# Altium schematic sheet main.SchDoc(main)
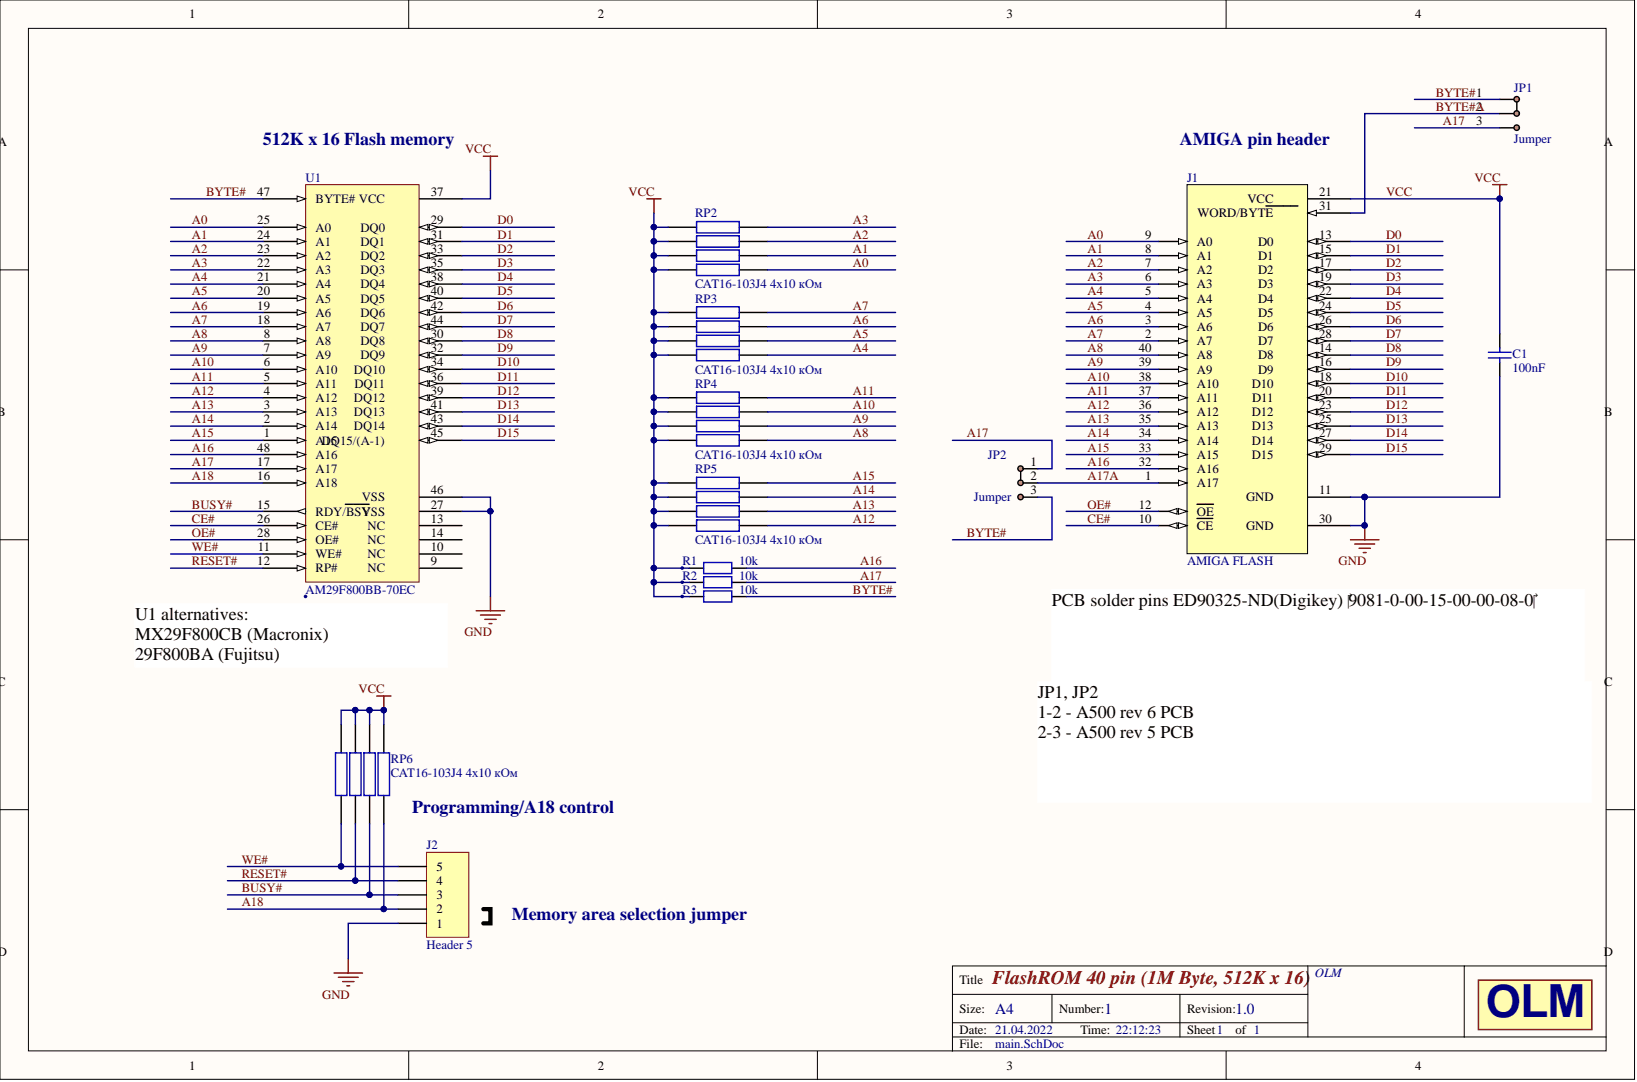
[diagram p0: main.SchDoc]

## Parts
C1  value 100nF  [SM/C_0603]  (hidden comment: Cap)
J1  AMIGA FLASH  [Header DIP40]
J2  Header 5  [HDR1X5]
JP1  Jumper  [Jumper-3-0.7x1.2-0.9mm]
JP2  Jumper  [Jumper-3-0.7x1.2-0.9mm]
R1  value 10k  [SM/R_0603]  (hidden comment: Резистор)
R2  value 10k  [SM/R_0603]  (hidden comment: Резистор)
R3  value 10k  [SM/R_0603]  (hidden comment: Резистор)
RP2  value CAT16-103J4 4х10 кОм  [RES_PACK_CAT16]  (hidden comment: Резисторная сборка)
RP3  value CAT16-103J4 4х10 кОм  [RES_PACK_CAT16]  (hidden comment: Резисторная сборка)
RP4  value CAT16-103J4 4х10 кОм  [RES_PACK_CAT16]  (hidden comment: Резисторная сборка)
RP5  value CAT16-103J4 4х10 кОм  [RES_PACK_CAT16]  (hidden comment: Резисторная сборка)
RP6  value CAT16-103J4 4х10 кОм  [RES_PACK_CAT16]  (hidden comment: Резисторная сборка)
U1  AM29F800BB-70EC  [TSOP-48]

## Nets
GND: C1.2 J1.11 J1.30 J2.1 U1.27 U1.46
A0: J1.9(A0) RP2.1 U1.25(A0)
A1: J1.8(A1) RP2.2 U1.24(A1)
A2: J1.7(A2) RP2.3 U1.23(A2)
A3: J1.6(A3) RP2.4 U1.22(A3)
A4: J1.5(A4) RP3.1 U1.21(A4)
A5: J1.4(A5) RP3.2 U1.20(A5)
A6: J1.3(A6) RP3.3 U1.19(A6)
A7: J1.2(A7) RP3.4 U1.18(A7)
A8: J1.40(A8) RP4.1 U1.8(A8)
A9: J1.39(A9) RP4.2 U1.7(A9)
A10: J1.38(A10) RP4.3 U1.6(A10)
A11: J1.37(A11) RP4.4 U1.5(A11)
A12: J1.36(A12) RP5.1 U1.4(A12)
A13: J1.35(A13) RP5.2 U1.3(A13)
A14: J1.34(A14) RP5.3 U1.2(A14)
A15: J1.33(A15) RP5.4 U1.1(A15)
A16: J1.32(A16) R1.2 U1.48(A16)
A17: JP1.3 JP2.1 R2.2 U1.17(A17)
A17A: J1.1(A17) JP2.2
A18: J2.2 RP6.1 U1.16(A18)
BUSY#: J2.3 RP6.2 U1.15(RDY/BSY)
BYTE#: JP1.1 JP2.3 R3.2 U1.47
BYTE#A: J1.31 JP1.2
CE#: J1.10(CE) U1.26(CE#)
D0: J1.13 U1.29
D1: J1.15 U1.31
D2: J1.17 U1.33
D3: J1.19 U1.35
D4: J1.22 U1.38
D5: J1.24 U1.40
D6: J1.26 U1.42
D7: J1.28 U1.44
D8: J1.14 U1.30
D9: J1.16 U1.32
D10: J1.18 U1.34
D11: J1.20 U1.36
D12: J1.23 U1.39
D13: J1.25 U1.41
D14: J1.27 U1.43
D15: J1.29 U1.45
OE#: J1.12(OE) U1.28(OE#)
RESET#: J2.4 RP6.3 U1.12(RP#)
VCC: C1.1 J1.21 R1.1 R2.1 R3.1 RP2.5 RP2.6 RP2.7 RP2.8 RP3.5 RP3.6 RP3.7 RP3.8 RP4.5 RP4.6 RP4.7 RP4.8 RP5.5 RP5.6 RP5.7 RP5.8 RP6.5 RP6.6 RP6.7 RP6.8 U1.37
WE#: J2.5 RP6.4 U1.11(WE#)

Not connected: U1 9 10 13 14

## Library data of the parts
C1 = Cap ceramic: CAP-100Nf; Component Kind: Standard; Library Name: New.SCHLIB
J1 = 27C4100: 256K x 16 EPROM; Class: Memory; Component Kind: Standard; Library Name: New.SCHLIB; SubClass: EPROM
J2 = Header 5: Header, 5-Pin; Component Kind: Standard; LatestRevisionDate: 17-Jul-2002; LatestRevisionNote: Re-released for DXP Platform.; Library Name: Miscellaneous Connectors.SchLib; Signal Integrity: Connector; Publisher: Altium Limited
JP1 JP2 = Jumper-3: Jumper Wire; Manufacturer: Generic Components; Component Kind: Standard; Library Name: New_home.SCHLIB; Published: 1999
R1-R3 = Res2: Resistor; Component Kind: Standard; LatestRevisionDate: 17-Jul-2002; LatestRevisionNote: Re-released for DXP Platform.; Library Name: Miscellaneous Devices.IntLib; PackageReference: AXIAL-0.4; Published: 8-Jun-2000; Signal Integrity: Res; Simulation: RESISTOR; Publisher: Altium Limited
RP2-RP5 = RES_PACK_4: Component Kind: Standard; Library Name: New.SCHLIB
RP6 = RES_PACK_4: Value: CAT16-103J4 4х10 кОм; Component Kind: Standard; Library Name: New.SCHLIB
U1 = AM29F800BB-70EC: 8Mb SMART 5 BOOT BLOCK FLASH MEMORY; Manufacturer: Micron Technology Inc; ManufacturerPN: MT28F800B5WP-8B; CategoryI: Integrated Circuits (ICs); CategoryII: NOR FLASH MEMORY; DesignedBy: Circuitry Parts; SchematicRev: A; CircuitryPartsPN: AM29F800BB-70EC; Component Kind: Standard; DesignedOn: 7/18/2005 3:14:54 PM; Library Name: NOR_FLASH.schlib; MfgURL: http://www.micron.com/; PackageName: TSOP48
